# Altium schematic sheet Serial Monitor Wide-Input Isolated Power Module Schematic.SchDoc("Serial Monitor Wide-Input Isolated Power Module Schematic")
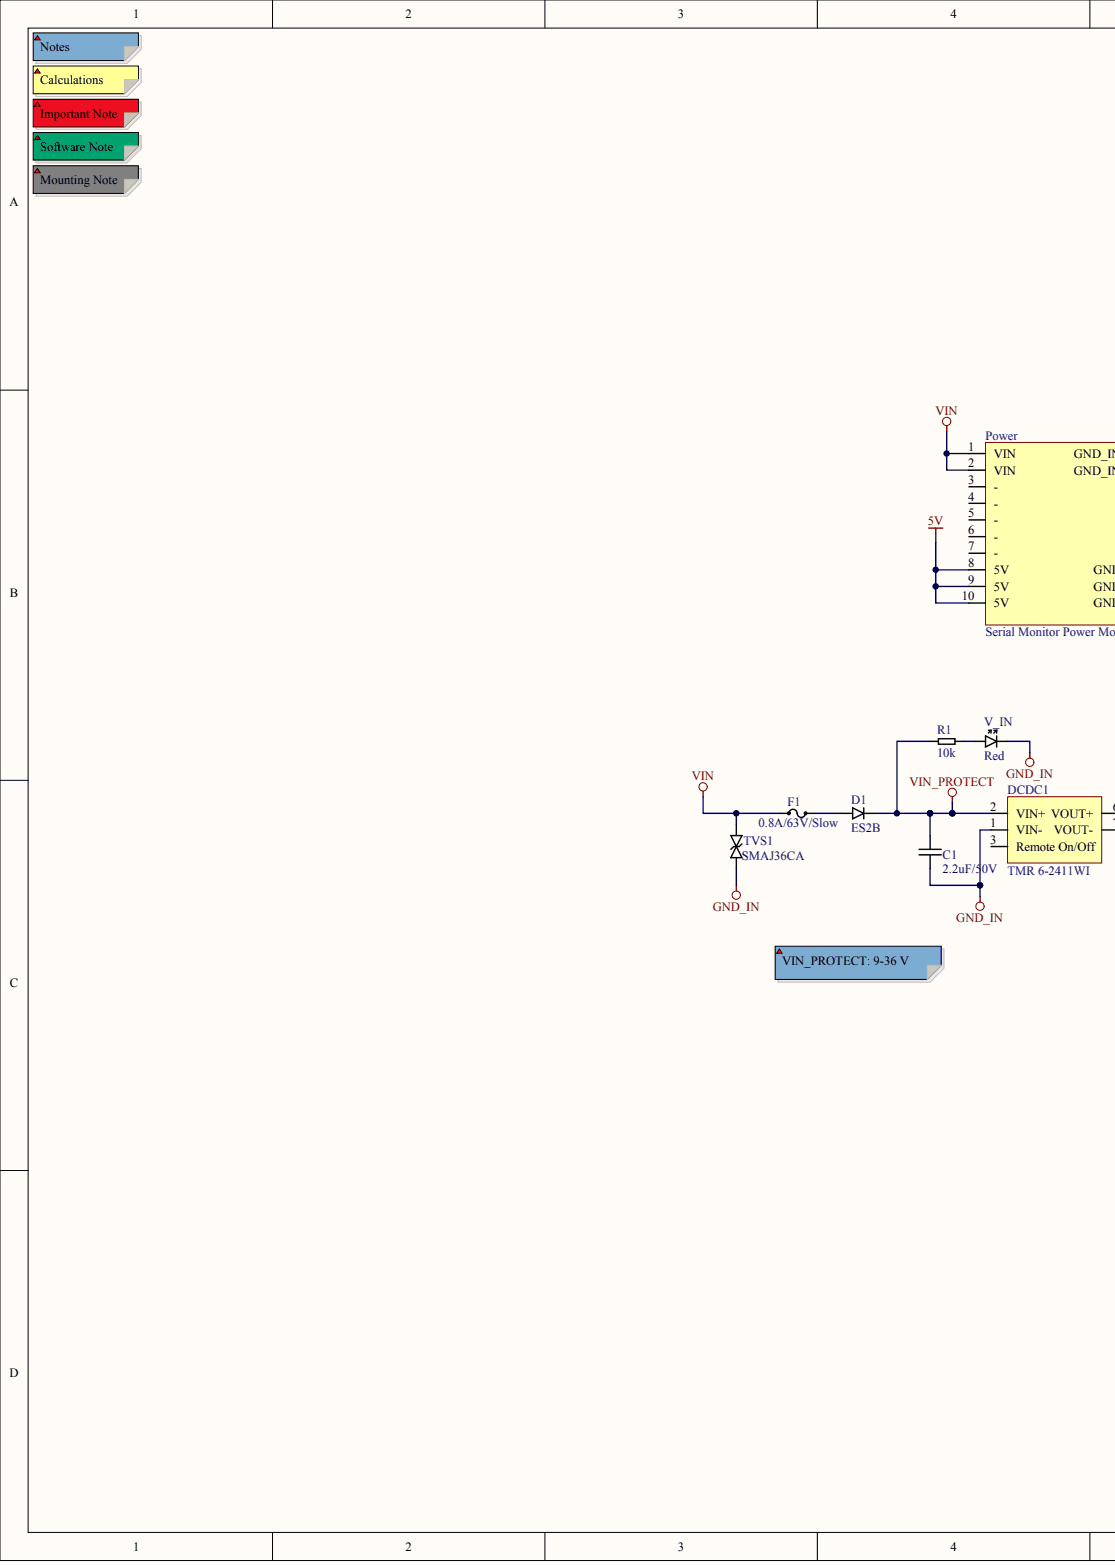
[diagram p0: Serial Monitor Wide-Input Isolated Power Module Schematic.SchDoc, left]
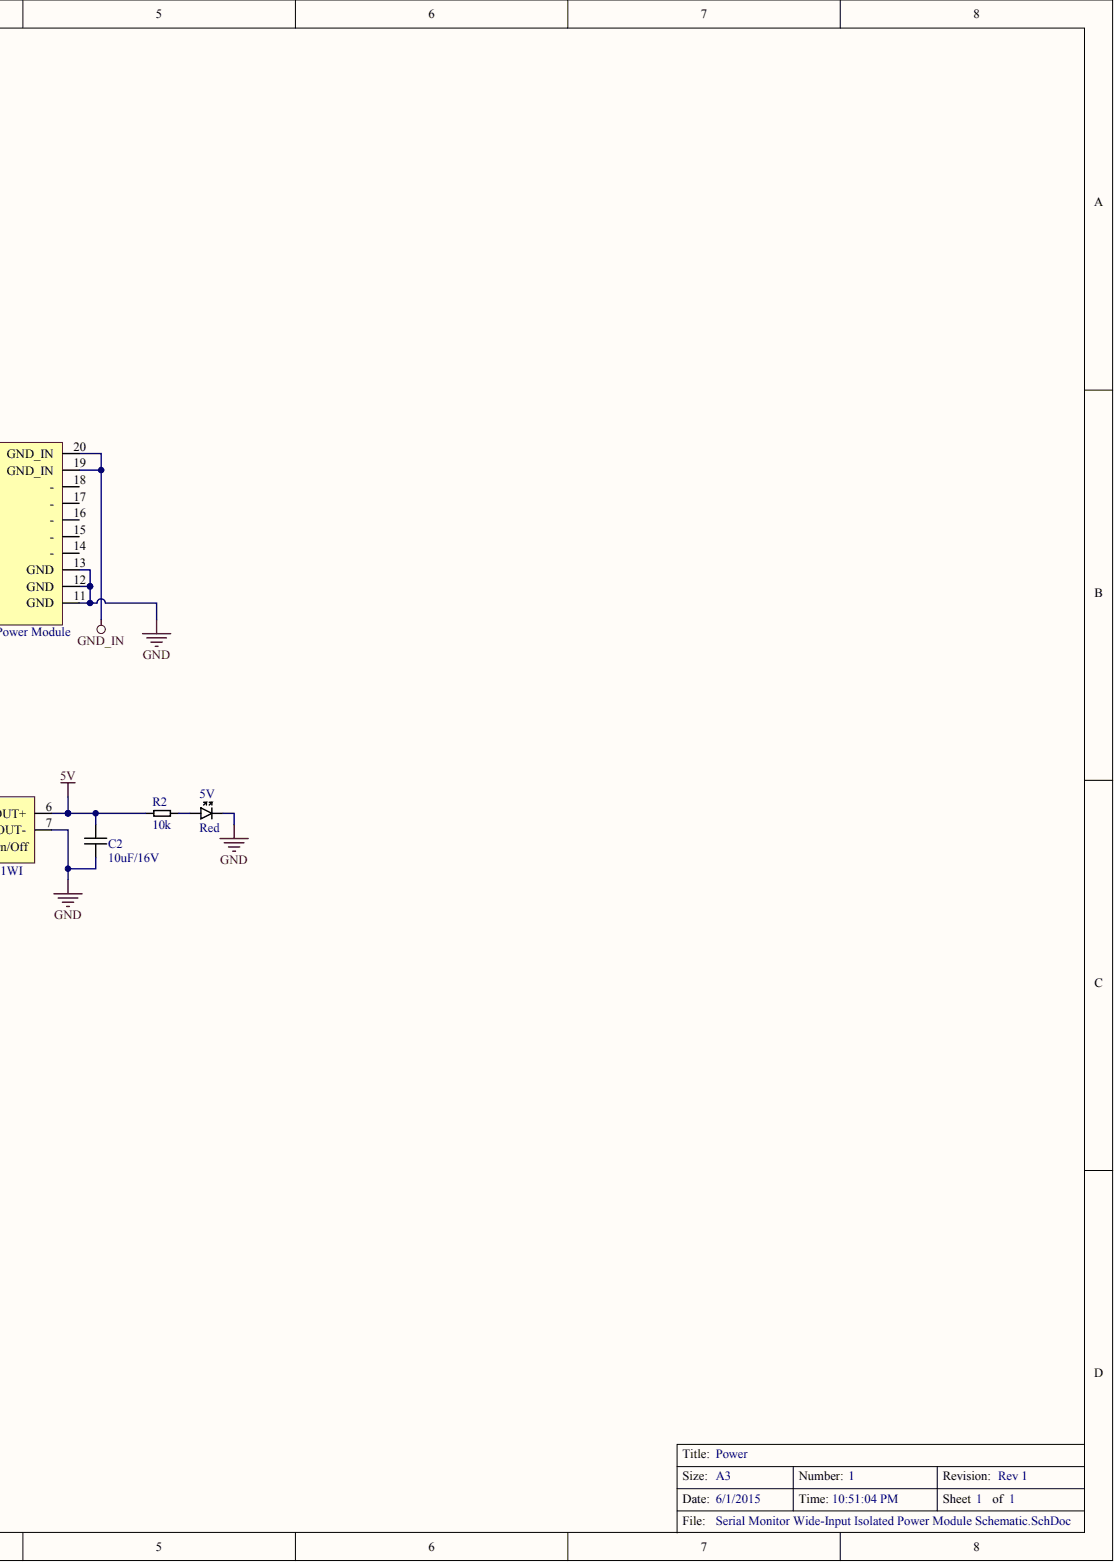
[diagram p1: Serial Monitor Wide-Input Isolated Power Module Schematic.SchDoc, right]

## Parts
5V  value Red  (hidden comment: LED_0603_Red)
C1  value 2.2uF/50V  [1206]  (hidden comment: Cap_1206_2.2uF/50V)
C2  value 10uF/16V  [0805]  (hidden comment: Cap_0805_10uF/16V)
D1  ES2B
DCDC1  TMR 6-2411WI  [8-SIP]
F1  value 0.8A/63V/Slow  [SMD 1206]  (hidden comment: Fuse_1206_0.8A_63V_Slow)
Power  Serial Monitor Power Module
R1  value 10k  [0603]  (hidden comment: Res_0603_10k)
R2  value 10k  [0603]  (hidden comment: Res_0603_10k)
TVS1  SMAJ36CA
V_IN  value Red  (hidden comment: LED_0603_Red)

## Nets
5V: C2.2 DCDC1.6(VOUT+) Power.8(5V) Power.9(5V) Power.10(5V) R2.2
GND: 5V.CATHODE C2.1 DCDC1.7(VOUT-) Power.11(GND) Power.12(GND) Power.13(GND)
GND_IN: C1.1 DCDC1.1(VIN-) Power.19(GND_IN) Power.20(GND_IN) TVS1.1 V_IN.CATHODE
VIN: F1.1 Power.1(VIN) Power.2(VIN) TVS1.2
VIN_PROTECT: C1.2 D1.1 DCDC1.2(VIN+) R1.2
Net5V_ANODE: 5V.ANODE R2.1
NetD1_2: D1.2 F1.2
NetR1_1: R1.1 V_IN.ANODE

Not connected: DCDC1 3(Remote_On/Off); Power 3(-) 4(-) 5(-) 6(-) 7(-) 14(-) 15(-) 16(-) 17(-) 18(-)

## Library data of the parts
5V V_IN = (no symbol name): Part No.: APT1608SRCPRV; Manufacturer: Kingbright; RS: 654-5420; DigiKey: 754-1122-1-ND; Price SEK (No VAT): 1; Mouser: 604-APT1608SRCPRV
C1 = (no symbol name): Part No.: GRM31CR71H225KA88L; Manufacturer: Murata; Mouser: 81-GRM31CR71H225KA88; Price SEK (No VAT): 2; DigiKey: 490-3367-1-ND
C2 = (no symbol name): Part No.: GRM21BR61C106KE15L; Manufacturer: Murata; DigiKey: 490-3886-1-ND; Price SEK (No VAT): 1,5; Mouser: 81-GRM21BR61C106KE15
D1 = (no symbol name): Manufacturer: Vishay; Part No.: 625-ES2B-E3/5BT; Mouser: ES2B-E3/5BT; DigiKey: ES2B-E3/52TGICT-ND; Price SEK (No VAT): 4; Value: ES2B
DCDC1 = (no symbol name): Manufacturer: Traco Power; RS: 783-3403; DigiKey: *; Part No.: TMR 6-2411WI; Price SEK (No VAT): 230; Mouser: *; Datasheet: http://www.tracopower.com/products/tmr3wi.pdf; Farnell: 2363818; Value: TMR 6-2411WI
F1 = (no symbol name): Part No.: SF-1206S080-2; Manufacturer: Bourns; Mouser: 652-SF-1206S080-2; Price SEK (No VAT): 5; DigiKey: SF-1206S080-2CT-ND; RS: *; Farnell: 2291894
R1 R2 = (no symbol name): Part No.: CRCW060310K0FKEA; Manufacturer: Vishay; Price SEK (No VAT): 0,1; Mouser: 71-CRCW0603-10K-E3; DigiKey: 541-10.0KHCT-ND
TVS1 = (no symbol name): Manufacturer: Bourns; Mouser: 652-SMAJ36CA; DigiKey: SMAJ36CABCT-ND; Price SEK (No VAT): 4; Part No.: SMAJ36CA; Value: SMAJ36CA
Power = (no symbol name): Value: Serial Monitor Power Module
